# Altium schematic sheet CPU_AP.SchDoc(CPU_AP)
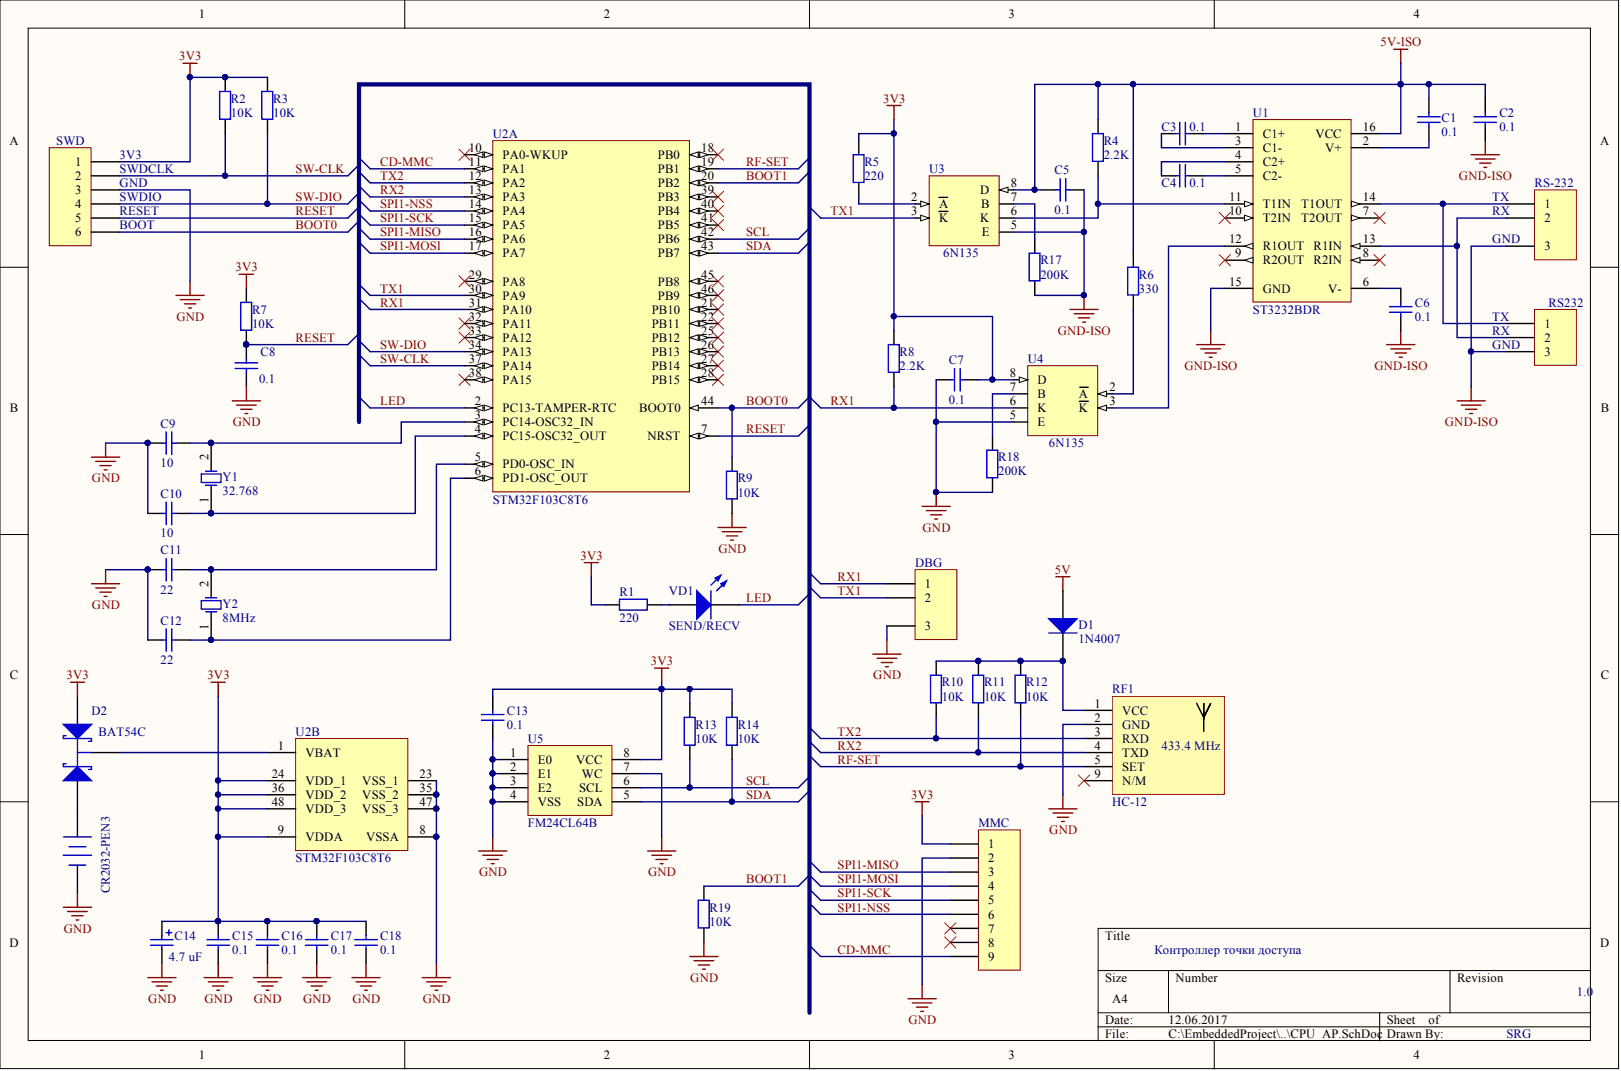
[diagram p0: CPU_AP.SchDoc]

## Parts
BAT1  value CR2032-PEN3  [CR2032-PEN3]  (hidden comment: BAT 3V3)
C1  value 0.1  [C1206]  (hidden comment: Cap)
C2  value 0.1  [C1206]  (hidden comment: Cap)
C3  value 0.1  [C1206]  (hidden comment: Cap)
C4  value 0.1  [C1206]  (hidden comment: Cap)
C5  value 0.1  [C1206]  (hidden comment: Cap)
C6  value 0.1  [C1206]  (hidden comment: Cap)
C7  value 0.1  [C1206]  (hidden comment: Cap)
C8  value 0.1  [C1206]  (hidden comment: Cap)
C9  value 10  [C1206]  (hidden comment: Cap)
C10  value 10  [C1206]  (hidden comment: Cap)
C11  value 22  [C1206]  (hidden comment: Cap)
C12  value 22  [C1206]  (hidden comment: Cap)
C13  value 0.1  [C1206]  (hidden comment: Cap)
C14  value 4.7 uF  [B]  (hidden comment: Polarized Capacitor)
C15  value 0.1  [C1206]  (hidden comment: Cap)
C16  value 0.1  [C1206]  (hidden comment: Cap)
C17  value 0.1  [C1206]  (hidden comment: Cap)
C18  value 0.1  [C1206]  (hidden comment: Cap)
D1  value 1N4007  PartNumber: 1N4007  [DO-41-300]  (hidden comment: Diode)
D2  value BAT54C  [SOT23_BAT54]  (hidden comment: Schottky Barrier Double Diode)
DBG  Header 3  [HDR1X4-1]  (value not drawn)
MMC  Header 9  [HDR1X9]  (value not drawn)
R1  value 220  [R1206]  (hidden comment: Res2)
R2  value 10K  [R1206]  (hidden comment: Res2)
R3  value 10K  [R1206]  (hidden comment: Res2)
R4  value 2.2K  [R1206]  (hidden comment: Res2)
R5  value 220  [R1206]  (hidden comment: Res2)
R6  value 330  [R1206]  (hidden comment: Res2)
R7  value 10K  [R1206]  (hidden comment: Res2)
R8  value 2.2K  [R1206]  (hidden comment: Res2)
R9  value 10K  [R1206]  (hidden comment: Res2)
R10  value 10K  [R1206]  (hidden comment: Res2)
R11  value 10K  [R1206]  (hidden comment: Res2)
R12  value 10K  [R1206]  (hidden comment: Res2)
R13  value 10K  [R1206]  (hidden comment: Res2)
R14  value 10K  [R1206]  (hidden comment: Res2)
R17  value 200K  [R1206]  (hidden comment: Res2)
R18  value 200K  [R1206]  (hidden comment: Res2)
R19  value 10K  [R1206]  (hidden comment: Res2)
RF1  HC-12  [HC-12-INTANT]
RS232  301  [TS-501 3P-2.5]  (value not drawn)
RS-232  Header 3  [HDR1X4-1]  (value not drawn)
SWD  Header 6  [HDR1X6]  (value not drawn)
U1  ST3232BDR  [SOIC16N_N]
U2  STM32F103C8T6  [STM-LQFP48_N]  (parts A,B)
U3  6N135  [DIL8-300]
U4  6N135  [DIL8-300]
U5  FM24CL64B  [SO8_M]
VD1  SEND/RECV  [LED1206]
Y1  value 32.768  [LED]  (hidden comment: XTAL)
Y2  value 8MHz  [HC49/4H_SMX]  (hidden comment: XTAL)

## Nets
3V3: C7.1 C13.2 C14.1 C15.2 C16.2 C17.2 C18.2 D2.2 MMC.1 R1.1 R2.2 R3.2 R5.1 R7.2 R8.2 R13.2 R14.2 SWD.1 U2.9(VDDA) U2.24(VDD_1) U2.36(VDD_2) U2.48(VDD_3) U4.8(D) U5.8(VCC)
5V: D1.1
5V-ISO: C1.1 C2.2 C5.2 R4.2 R6.2 U1.16(VCC) U3.8(D)
GND: BAT1.2 C7.2 C8.2 C9.1 C10.1 C11.1 C12.1 C13.1 C14.2 C15.1 C16.1 C17.1 C18.1 DBG.3 MMC.2 R9.1 R18.1 R19.1 RF1.2(GND) SWD.3 U2.8(VSSA) U2.23(VSS_1) U2.35(VSS_2) U2.47(VSS_3) U4.5(E) U5.1(E0) U5.2(E1) U5.3(E2) U5.4(VSS) U5.7(WC)
GND-ISO: C2.1 C5.1 C6.1 R17.1 RS232.3 RS-232.3 U1.15(GND) U3.5(E)
BOOT0: R9.2 SWD.6 U2.44(BOOT0)
BOOT1: R19.2 U2.20(PB2)
CD-MMC: MMC.9 U2.11(PA1)
LED: U2.2(PC13-TAMPER-RTC) VD1.2
RESET: C8.1 R7.1 SWD.5 U2.7(NRST)
RF-SET: R12.1 RF1.5(SET) U2.19(PB1)
RX1: DBG.1 R8.1 U2.31(PA10) U4.6(K)
RX2: R11.1 RF1.4(TXD) U2.13(PA3)
SCL: R13.1 U2.42(PB6) U5.6(SCL)
SDA: R14.1 U2.43(PB7) U5.5(SDA)
SPI1-MISO: MMC.3 U2.16(PA6)
SPI1-MOSI: MMC.4 U2.17(PA7)
SPI1-NSS: MMC.6 U2.14(PA4)
SPI1-SCK: MMC.5 U2.15(PA5)
SW-CLK: R2.1 SWD.2 U2.37(PA14)
SW-DIO: R3.1 SWD.4 U2.34(PA13)
TX1: DBG.2 U2.30(PA9) U3.3(K)
TX2: R10.1 RF1.3(RXD) U2.12(PA2)
NetBAT1_1: BAT1.1 D2.1
NetC1_2: C1.2 U1.2(V+)
NetC3_1: C3.1 U1.1(C1+)
NetC3_2: C3.2 U1.3(C1-)
NetC4_1: C4.1 U1.5(C2-)
NetC4_2: C4.2 U1.4(C2+)
NetC6_2: C6.2 U1.6(V-)
NetC9_2: C9.2 U2.3(PC14-OSC32_IN) Y1.2
NetC10_2: C10.2 U2.4(PC15-OSC32_OUT) Y1.1
NetC11_2: C11.2 U2.5(PD0-OSC_IN) Y2.2
NetC12_2: C12.2 U2.6(PD1-OSC_OUT) Y2.1
NetD1_2: D1.2 R10.2 R11.2 R12.2 RF1.1(VCC)
NetD2_3: D2.3 U2.1(VBAT)
NetR1_2: R1.2 VD1.1
NetR4_1: R4.1 U1.11(T1IN) U3.6(K)
NetR5_2: R5.2 U3.2(A)
NetR6_1: R6.1 U4.2(A)
NetR17_2: R17.2 U3.7(B)
NetR18_2: R18.2 U4.7(B)
NetRS232_1: RS232.1 RS-232.1 U1.14(T1OUT)
NetRS232_2: RS232.2 RS-232.2 U1.13(R1IN)
NetU1_12: U1.12(R1OUT) U4.3(K)
N00056:

Not connected: MMC 7 8; RF1 9(N/M); U1 7(T2OUT) 8(R2IN) 9(R2OUT) 10(T2IN); U2 10(PA0-WKUP) 18(PB0) 21(PB10) 22(PB11) 25(PB12) 26(PB13) 27(PB14) 28(PB15) 29(PA8) 32(PA11) 33(PA12) 38(PA15) 39(PB3) 40(PB4) 41(PB5) 45(PB8) 46(PB9)

## Library data of the parts
BAT1 = Battery: Multicell Battery; DeliveryTime: 7; Value: CR2032-PEN3; Component Kind: Standard; Library Name: Miscellaneous Devices.IntLib; PartNumber: CR2032-PEN3; Price: 42.00
C1-C8 C13 C15-C18 = Cap: Capacitor; PartNumber: GRM31CR72A104K; DeliveryTime: 7; Component Kind: Standard; Library Name: Miscellaneous Devices.IntLib; Price: 10.00; Signal Integrity: Cap; Simulation: CAP
C9 C10 = Cap: Capacitor; PartNumber: GRM31A7U3D100JW31D; DeliveryTime: 7; Component Kind: Standard; Library Name: Miscellaneous Devices.IntLib; Price: 4.40; Signal Integrity: Cap; Simulation: CAP
C11 C12 = Cap: Capacitor; PartNumber: GRM3165C1H220J; DeliveryTime: 7; Component Kind: Standard; Library Name: Miscellaneous Devices.IntLib; Price: 5.0; Signal Integrity: Cap; Simulation: CAP
C14 = Cap Pol2: PartNumber: TECAP, 4.7мкф, 16В, тип В, 10% SMD; DeliveryTime: 7; Value: 4.7 uF; Component Kind: Standard; Description: Polarized Capacitor; Library Name: Miscellaneous Devices.IntLib; Price: 12.00; Signal Integrity: Cap Pol; Simulation: CAP
D1 = Diode: Default Diode; DeliveryTime: 7; Component Kind: Standard; Library Name: Miscellaneous Devices.IntLib; Price: 3.00; Signal Integrity: Diode; Simulation: DIODE
D2 = BAT54C: DeliveryTime: 7; Component Kind: Standard; Description: Schottky Barrier Double Diode; Library Name: Philips Discrete Diode - Schottky.IntLib; PartNUmber: BAT54C; Price: 4.00; Signal Integrity: BAT54C; Simulation: BAT54C
DBG RS-232 = Header 3: Header, 3-Pin; Component Kind: Standard; LatestRevisionDate: 17-Jul-2002; LatestRevisionNote: Re-released for DXP Platform.; Library Name: Schlib1.SchLib; Signal Integrity: Connector; value: Header 3; Publisher: Altium Limited
MMC = Header 9: Header, 9-Pin; Value: Connector; Header; 9; Component Kind: Standard; LatestRevisionDate: 17-Jul-2002; LatestRevisionNote: Re-released for DXP Platform.; Library Name: Miscellaneous Connectors.IntLib; Signal Integrity: Connector; Publisher: Altium Limited
R1 R5 = Res2: Resistor; PartNumber: RC1206JK-07220RL; DeliveryTime: 7; Component Kind: Standard; Library Name: Miscellaneous Devices.IntLib; Price: 0.90; Signal Integrity: Res; Simulation: RESISTOR
R2 R3 R7 R9-R14 R19 = Res2: Resistor; PartNumber: RC1206JK-0710KL; DeliveryTime: 7; Component Kind: Standard; Library Name: Miscellaneous Devices.IntLib; Price: 0.90; Signal Integrity: Res; Simulation: RESISTOR
R4 R8 = Res2: Resistor; PartNumber: RC1206JK-072K2L; DeliveryTime: 7; Component Kind: Standard; Library Name: Miscellaneous Devices.IntLib; Price: 0.90; Signal Integrity: Res; Simulation: RESISTOR
R6 = Res2: Resistor; PartNumber: RC1206JK-07330RL; DeliveryTime: 7; Component Kind: Standard; Library Name: Miscellaneous Devices.IntLib; Price: 0.90; Signal Integrity: Res; Simulation: RESISTOR
R17 R18 = Res2: Resistor; PartNumber: RC1206JK-07200KL; DeliveryTime: 7; Component Kind: Standard; Library Name: Miscellaneous Devices.IntLib; Price: 0.90; Signal Integrity: Res; Simulation: RESISTOR
RF1 = HC-12: Wireless Serial Port Communication Module; Component Kind: Standard; Library Name: Schlib1.SchLib; PartNumber: HC-12; Price: 200.00; Value: HC-12
RS232 = Header 3: Header, 3-Pin; PartNumber: 301-031-12; DeliveryTime: 7; Value: 301-031-12; Component Kind: Standard; Library Name: Miscellaneous Connectors.IntLib; Price: 16.00; Signal Integrity: Connector
SWD = Header 6: Header, 6-Pin; Component Kind: Standard; LatestRevisionDate: 17-Jul-2002; LatestRevisionNote: Re-released for DXP Platform.; Library Name: Miscellaneous Connectors.IntLib; Signal Integrity: Connector; Publisher: Altium Limited
U1 = ST3232BDR: Dual RS-232 Transmitter/Receiver; DeliveryTime: 7; Component Kind: Standard; Library Name: Schlib1.SchLib; PartNUmber: ST3232BDR; Price: 35.00; Value: ST3232BDR
U2 = STM32F103C8T6: ARM Cortex-M3 32-bit MCU, 64 KB Flash, 20 KB Internal RAM, 37 I/Os, 48-pin LQFP, -40 to 85 degC, Tray; DeliveryTime: 7; Component Kind: Standard; Library Name: STMicroelectronics STM32 F1.IntLib; PartNumber: STM32F103C8T6; Price: 140.00; Value: STM32F103C8T6
U3 U4 = 6N135: PartNumber: 6N136; DeliveryTime: 7; Value: 6N136; Component Kind: Standard; Price: 57.00; Library Name: Opto Coupler.IntLib
U5 = FM24CL64B: DeliveryTime: 5; Component Kind: Standard; Description: FM24CL64B; Library Name: Schlib1.SchLib; PartNumber: FM24CL64B; Price: 200.00; Value: FM24CL64B
VD1 = LED0: SMD LED; PartNumber: KPDT-3216PGC; DeliveryTime: 7; value: GREEN; Component Kind: Standard; Library Name: Miscellaneous Devices.IntLib; Price: 9.00; Simulation: LED0
Y1 = XTAL: Crystal Oscillator; PartNumber: MCRJ332768F1220HOW; DeliveryTime: 21; Component Kind: Standard; Library Name: Miscellaneous Devices.IntLib; Price: 28; Simulation: XTAL
Y2 = XTAL: Crystal Oscillator; PartNumber: LFXTAL020423; DeliveryTime: 21; Component Kind: Standard; Library Name: Miscellaneous Devices.IntLib; Price: 57.00; Simulation: XTAL
